# Altium schematic sheet Sheet.SchDoc
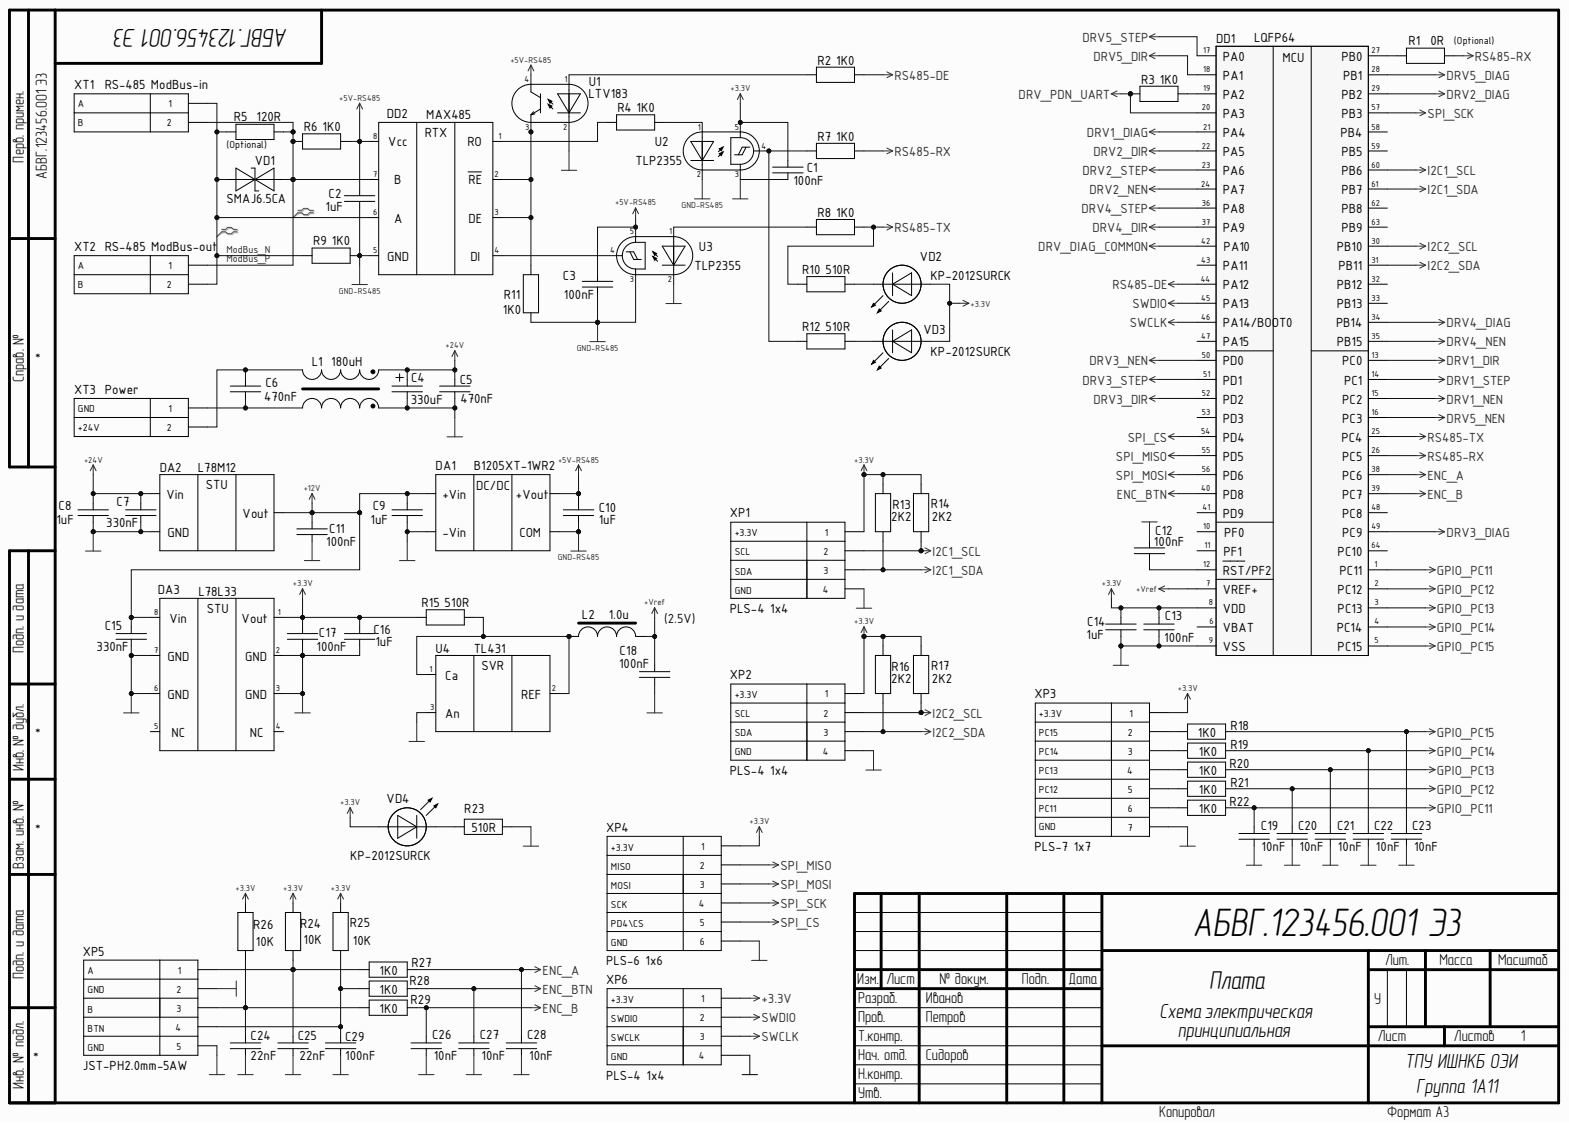
[diagram p0: Sheet.SchDoc]

## Parts
C1  value 100nF  [CAP0805]  (hidden comment: CAP0805 100nF±10%, 50 V, X7R)
C2  value 1uF  [CAP0805]  (hidden comment: CAP0805 1uF±10%, 50 V, X7R)
C3  value 100nF  [CAP0805]  (hidden comment: CAP0805 100nF±10%, 50 V, X7R)
C4  value 330uF  [CapAl10X16X5.0]  (hidden comment: CapAl10X16X5.0mm 330uF, 50 V)
C5  value 470nF  [CAP0805]  (hidden comment: CAP0805 470nF±10%, 50 V, X7R)
C6  value 470nF  [CAP0805]  (hidden comment: CAP0805 470nF±10%, 50 V, X7R)
C7  value 330nF  [CAP0805]  (hidden comment: CAP0805 330nF±10%, 50 V, X7R)
C8  value 1uF  [CAP0805]  (hidden comment: CAP0805 1uF±10%, 50 V, X7R)
C9  value 1uF  [CAP0805]  (hidden comment: CAP0805 1uF±10%, 50 V, X7R)
C10  value 1uF  [CAP0805]  (hidden comment: CAP0805 1uF±10%, 50 V, X7R)
C11  value 100nF  [CAP0805]  (hidden comment: CAP0805 100nF±10%, 50 V, X7R)
C12  value 100nF  [CAP0805]  (hidden comment: CAP0805 100nF±10%, 50 V, X7R)
C13  value 100nF  [CAP0805]  (hidden comment: CAP0805 100nF±10%, 50 V, X7R)
C14  value 1uF  [CAP0805]  (hidden comment: CAP0805 1uF±10%, 50 V, X7R)
C15  value 330nF  [CAP0805]  (hidden comment: CAP0805 330nF±10%, 50 V, X7R)
C16  value 1uF  [CAP0805]  (hidden comment: CAP0805 1uF±10%, 50 V, X7R)
C17  value 100nF  [CAP0805]  (hidden comment: CAP0805 100nF±10%, 50 V, X7R)
C18  value 100nF  [CAP0805]  (hidden comment: CAP0805 100nF±10%, 50 V, X7R)
C19  value 10nF  [CAP0805]  (hidden comment: CAP0805 10nF±5%, 50 V, NP0)
C20  value 10nF  [CAP0805]  (hidden comment: CAP0805 10nF±5%, 50 V, NP0)
C21  value 10nF  [CAP0805]  (hidden comment: CAP0805 10nF±5%, 50 V, NP0)
C22  value 10nF  [CAP0805]  (hidden comment: CAP0805 10nF±5%, 50 V, NP0)
C23  value 10nF  [CAP0805]  (hidden comment: CAP0805 10nF±5%, 50 V, NP0)
C24  value 22nF  [CAP0805]  (hidden comment: CAP0805 22nF±10%, 50 V, X7R)
C25  value 22nF  [CAP0805]  (hidden comment: CAP0805 22nF±10%, 50 V, X7R)
C26  value 10nF  [CAP0805]  (hidden comment: CAP0805 10nF±5%, 50 V, NP0)
C27  value 10nF  [CAP0805]  (hidden comment: CAP0805 10nF±5%, 50 V, NP0)
C28  value 10nF  [CAP0805]  (hidden comment: CAP0805 10nF±5%, 50 V, NP0)
C29  value 100nF  [CAP0805]  (hidden comment: CAP0805 100nF±10%, 50 V, X7R)
DA1  Model: B1205XT-1WR2  [B_XT-1WR2]  (hidden comment: B1205XT-1WR2 (12 V, 5 V))
DA2  Model: L78M12  [D-PAK]  (hidden comment: L78M12 (D-PAK))
DA3  Model: L78L33  [SO-8 Narrow]  (hidden comment: L78L33 (SO-8 Narrow))
DD1  STM32G071RBTx  [LQFP64]  (value not drawn)
DD2  Model: MAX485  [SO-8 Narrow]  (hidden comment: MAX485 (2.5 Mbit, 4.75 V ... 5.25 V ))
L1  value 180uH  [BU10]  (hidden comment: Common Mode Chokes 19*17*22,5mm: 180uH, 1.2A)
L2  value 1.0u  [IND0805]  (hidden comment: IND0805 0805 (2.0*1.25mm) 1.0u)
R1  value 0R  [RES0805]  (hidden comment: RES0805 0R±5%)
R2  value 1K0  [RES0805]  (hidden comment: RES0805 1K0±5%)
R3  value 1K0  [RES0805]  (hidden comment: RES0805 1K0±5%)
R4  value 1K0  [RES0805]  (hidden comment: RES0805 1K0±5%)
R5  value 120R  [RES1210]  (hidden comment: RES1210 120R±5%)
R6  value 1K0  [RES0805]  (hidden comment: RES0805 1K0±5%)
R7  value 1K0  [RES0805]  (hidden comment: RES0805 1K0±5%)
R8  value 1K0  [RES0805]  (hidden comment: RES0805 1K0±5%)
R9  value 1K0  [RES0805]  (hidden comment: RES0805 1K0±5%)
R10  value 510R  [RES0805]  (hidden comment: RES0805 510R±5%)
R11  value 1K0  [RES0805]  (hidden comment: RES0805 1K0±5%)
R12  value 510R  [RES0805]  (hidden comment: RES0805 510R±5%)
R13  value 2K2  [RES0805]  (hidden comment: RES0805 2K2±5%)
R14  value 2K2  [RES0805]  (hidden comment: RES0805 2K2±5%)
R15  value 510R  [RES0805]  (hidden comment: RES0805 510R±5%)
R16  value 2K2  [RES0805]  (hidden comment: RES0805 2K2±5%)
R17  value 2K2  [RES0805]  (hidden comment: RES0805 2K2±5%)
R18  value 1K0  [RES0805]  (hidden comment: RES0805 1K0±5%)
R19  value 1K0  [RES0805]  (hidden comment: RES0805 1K0±5%)
R20  value 1K0  [RES0805]  (hidden comment: RES0805 1K0±5%)
R21  value 1K0  [RES0805]  (hidden comment: RES0805 1K0±5%)
R22  value 1K0  [RES0805]  (hidden comment: RES0805 1K0±5%)
R23  value 510R  [RES0805]  (hidden comment: RES0805 510R±5%)
R24  value 10K  [RES0805]  (hidden comment: RES0805 10K±5%)
R25  value 10K  [RES0805]  (hidden comment: RES0805 10K±5%)
R26  value 10K  [RES0805]  (hidden comment: RES0805 10K±5%)
R27  value 1K0  [RES0805]  (hidden comment: RES0805 1K0±5%)
R28  value 1K0  [RES0805]  (hidden comment: RES0805 1K0±5%)
R29  value 1K0  [RES0805]  (hidden comment: RES0805 1K0±5%)
U1  Model: LTV183  [SOP-4]  (hidden comment: LTV183 (80 V, 50 mA))
U2  Model: TLP2355  [SO-6 5pin]  (hidden comment: TLP2355 (5 Mbit, 2.7 V ... 5.5 V ))
U3  Model: TLP2355  [SO-6 5pin]  (hidden comment: TLP2355 (5 Mbit, 2.7 V ... 5.5 V ))
U4  Model: TL431  [SOT23-3]  (hidden comment: TL431 (SOT23-3))
VD1  Model: SMAJ6.5CA  [SMA Bidirectional]  (hidden comment: SMAJ6.5CA (6.5 V, 400 W, SMA Bidirectional))
VD2  Model: KP-2012SURCK  [LED0805 RED]  (hidden comment: KP-2012SURCK (2.0V, 25mA, LED0805 RED))
VD3  Model: KP-2012SURCK  [LED0805 RED]  (hidden comment: KP-2012SURCK (2.0V, 25mA, LED0805 RED))
VD4  Model: KP-2012SURCK  [LED0805 RED]  (hidden comment: KP-2012SURCK (2.0V, 25mA, LED0805 RED))
XP1  PLS-4 1х4  Pin 1 Name: +3.3V  Pin 2 Name: SCL  Pin 3 Name: SDA  Pin 4 Name: GND  [PLS-1-4]
XP2  PLS-4 1х4  Pin 1 Name: +3.3V  Pin 2 Name: SCL  Pin 3 Name: SDA  Pin 4 Name: GND  [PLS-1-4]
XP3  PLS-7 1х7  Pin 1 Name: +3.3V  Pin 2 Name: PC15  Pin 3 Name: PC14  Pin 4 Name: PC13  Pin 5 Name: PC12  Pin 6 Name: PC11  Pin 7 Name: GND  [PLS-1-7]
XP4  PLS-6 1х6  Pin 1 Name: +3.3V  Pin 2 Name: MISO  Pin 3 Name: MOSI  Pin 4 Name: SCK  Pin 5 Name: PD4\CS  Pin 6 Name: GND  [PLS-1-6]
XP5  JST-PH2.0mm-5AW  Pin 1 Name: A  Pin 2 Name: GND  Pin 3 Name: B  Pin 4 Name: BTN  [JST-PH2.0MM-5AW]
XP6  PLS-4 1х4  Pin 1 Name: +3.3V  Pin 2 Name: SWDIO  Pin 3 Name: SWCLK  Pin 4 Name: GND  [PLS-1-4]
XT1  Name Connector: RS-485 ModBus-in  Pin 1 Name: A  Pin 2 Name: B  [DG306-5.0-2P]  (hidden comment: PCB Screw Terminal Blocks 2-Pin, Pitch 5mm)
XT2  Name Connector: RS-485 ModBus-out  Pin 1 Name: A  Pin 2 Name: B  [DG306-5.0-2P]  (hidden comment: PCB Screw Terminal Blocks 2-Pin, Pitch 5mm)
XT3  Name Connector: Power  Pin 1 Name: GND  Pin 2 Name: +24V  [DG306-5.0-2P]  (hidden comment: PCB Screw Terminal Blocks 2-Pin, Pitch 5mm)

## Nets
+3.3V: C1.2 C13.2 C14.1 C16.1 C17.2 DA3.1(Vout) DD1.8(VDD) R13.2 R14.2 R15.2 R16.2 R17.2 R24.1 R25.1 R26.2 U2.5 VD2.Anode VD3.Anode VD4.Anode XP1.1 XP2.1 XP3.1 XP4.1 XP6.1
+5V-RS485: C2.1 C3.2 C10.2 DA1.+Vout DD2.8(Vcc) R6.2 U1.4 U3.5
+12V: C9.2 C11.2 C15.2 DA1.+Vin DA2.Output DA3.8(Vin)
+24V: C4.1 C5.1 C7.2 C8.2 DA2.Input L1.InA
+Vref: C18.2 DD1.7(VREF+) L2.2
DRV1_DIAG: DD1.21(PA4)
DRV1_DIR: DD1.13(PC0)
DRV1_NEN: DD1.15(PC2)
DRV1_STEP: DD1.14(PC1)
DRV2_DIAG: DD1.29(PB2)
DRV2_DIR: DD1.22(PA5)
DRV2_NEN: DD1.24(PA7)
DRV2_STEP: DD1.23(PA6)
DRV3_DIAG: DD1.49(PC9)
DRV3_DIR: DD1.52(PD2)
DRV3_NEN: DD1.50(PD0)
DRV3_STEP: DD1.51(PD1)
DRV4_DIAG: DD1.34(PB14)
DRV4_DIR: DD1.37(PA9)
DRV4_NEN: DD1.35(PB15)
DRV4_STEP: DD1.36(PA8)
DRV5_DIAG: DD1.28(PB1)
DRV5_DIR: DD1.18(PA1)
DRV5_NEN: DD1.16(PC3)
DRV5_STEP: DD1.17(PA0)
DRV_DIAG_COMMON: DD1.42(PA10)
DRV_PDN_UART: DD1.20(PA3) R3.1
ENC_A: C28.2 DD1.38(PC6) R27.2
ENC_B: C26.2 DD1.39(PC7) R29.2
ENC_BTN: C27.2 DD1.40(PD8) R28.2
GND: C1.1 C4.2 C5.2 C7.1 C8.1 C9.1 C11.1 C12.2 C13.1 C14.2 C15.1 C16.2 C17.1 C18.1 C19.1 C20.1 C21.1 C22.1 C23.1 C24.1 C25.1 C26.1 C27.1 C28.1 C29.1 DA1.-Vin DA2.GND DA3.2(GND) DA3.3(GND) DA3.6(GND) DA3.7(GND) DD1.9(VSS) L1.InB R23.2 U1.2 U2.3 U3.2 U4.3 XP1.4 XP2.4 XP3.7 XP4.6 XP5.2 XP5.5 XP6.4
GND-RS485: C2.2 C3.1 C10.1 DA1.COM DD2.5(GND) R9.2 R11.1 U2.2 U3.3
GPIO_PC11: C19.2 DD1.1(PC11) R22.2
GPIO_PC12: C20.2 DD1.2(PC12) R21.2
GPIO_PC13: C21.2 DD1.3(PC13) R20.2
GPIO_PC14: C22.2 DD1.4(PC14) R19.2
GPIO_PC15: C23.2 DD1.5(PC15) R18.2
I2C1_SCL: DD1.60(PB6) R14.1 XP1.2
I2C1_SDA: DD1.61(PB7) R13.1 XP1.3
I2C2_SCL: DD1.30(PB10) R17.1 XP2.2
I2C2_SDA: DD1.31(PB11) R16.1 XP2.3
RS485-DE: DD1.44(PA12) R2.2
RS485-RX: DD1.26(PC5) R1.2 R7.2
RS485-TX: DD1.25(PC4) R8.2 R10.2
SPI_CS: DD1.54(PD4) XP4.5
SPI_MISO: DD1.55(PD5) XP4.2
SPI_MOSI: DD1.56(PD6) XP4.3
SPI_SCK: DD1.57(PB3) XP4.4
SWCLK: DD1.46(PA14/BOOT0) XP6.3
SWDIO: DD1.45(PA13) XP6.2
ModBus_N: DD2.6(A) R5.1 R9.1 VD1.1 XT1.1 XT2.2
ModBus_P: DD2.7(B) R5.2 R6.1 VD1.2 XT1.2 XT2.1
NetC6_1: C6.1 L1.OutA XT3.2
NetC6_2: C6.2 L1.OutB XT3.1
NetC12_1: C12.1 DD1.12(RST/PF2)
NetC24_2: C24.2 R26.1 R29.1 XP5.3
NetC25_2: C25.2 R24.2 R27.1 XP5.1
NetC29_2: C29.2 R25.2 R28.1 XP5.4
NetDD1_19: DD1.19(PA2) R3.2
NetDD1_27: DD1.27(PB0) R1.1
NetDD2_1: DD2.1(RO) R4.1
NetDD2_2: DD2.2(RE) DD2.3(DE) R11.2 U1.3
NetDD2_4: DD2.4(DI) U3.4
NetL2_1: L2.1 R15.1 U4.1 U4.2
NetR2_1: R2.1 U1.1
NetR4_2: R4.2 U2.1
NetR7_1: R7.1 R12.2 U2.4
NetR8_1: R8.1 U3.1
NetR10_1: R10.1 VD2.Cathode
NetR12_1: R12.1 VD3.Cathode
NetR18_1: R18.1 XP3.2
NetR19_1: R19.1 XP3.3
NetR20_1: R20.1 XP3.4
NetR21_1: R21.1 XP3.5
NetR22_1: R22.1 XP3.6
NetR23_1: R23.1 VD4.Cathode

Not connected: DA3 4(NC) 5(NC); DD1 6(VBAT) 10(PF0) 11(PF1) 32(PB12) 33(PB13) 41(PD9) 43(PA11) 47(PA15) 48(PC8) 53(PD3) 58(PB4) 59(PB5) 62(PB8) 63(PB9) 64(PC10)

## Library data of the parts
C1 C3 C11-C13 C17 C18 C29 = C0066: GENERAL PURPOSE CLASS 2 CERAMIC CAPACITORS CAP0805 100nF±10% 50 V X7R; Manufacture: Yageo; PartNumber: CC0805KRX7R9BB104; Database Table Name: Capacitors SMD$; Tolerance: ±10%; Voltage: 50 V; Case: 0805 (2.0*1.25mm); Component Kind: Standard; ComponentLink1Description: GENERAL PURPOSE CLASS 2 CERAMIC CAPACITORS; Dielectric: X7R; ID: C0066; Library Name: Passive DataBase.DbLib
  C12 C13 C18 C29: ComponentLink1URL: #VALUE!
  C1 C3 C11 C17: ComponentLink1URL: D:\Altium\AD24\Library\Passives Library\DataSheet\General Purpose & High Capacitance CERAMIC CAPACITOR CLASS II (Yageo).pdf
C2 C8-C10 C14 C16 = C0072: GENERAL PURPOSE CLASS 2 CERAMIC CAPACITORS CAP0805 1uF±10% 50 V X7R; Manufacture: Yageo; PartNumber: CC0805KRX7R9BB105; Database Table Name: Capacitors SMD$; Tolerance: ±10%; Voltage: 50 V; Case: 0805 (2.0*1.25mm); Component Kind: Standard; ComponentLink1Description: GENERAL PURPOSE CLASS 2 CERAMIC CAPACITORS; Dielectric: X7R; ID: C0072; Library Name: Passive DataBase.DbLib
  C2 C14 C16: ComponentLink1URL: D:\Altium\AD24\Library\Passives Library\DataSheet\General Purpose & High Capacitance CERAMIC CAPACITOR CLASS II (Yageo).pdf
  C8-C10: ComponentLink1URL: C:\Users\ASGord\OneDrive\Documents\Altium Libraries\Passives Library\DataSheet\General Purpose & High Capacitance CERAMIC CAPACITOR CLASS II (Yageo).pdf
C4 = CAL1102: MINIATURIZED ALUMINUM ELECTROLYTIC CAPACITORS CapAl10X16X5.0 330uF±20% 50 V 105⁰С; Manufacture: Panasonic; PartNumber: EEUHD1H331; Current: 410 mA (100 kHz); Database Table Name: Capacitor Al THD$; Tolerance: ±20%; Voltage: 50 V; Case: 10X16X5.0mm; Component Kind: Standard; ComponentLink1Description: MINIATURIZED ALUMINUM ELECTROLYTIC CAPACITORS; ID: CAL1102; Library Name: Passive DataBase.DbLib; Series: HD; Temperature: 105⁰С; ComponentLink1URL: C:\Users\ASGord\OneDrive\Documents\Altium Libraries\Passives Library\DataSheet\Aluminum Electrolytic Capacitors (Panasonic).pdf
C5 C6 = C0070: GENERAL PURPOSE CLASS 2 CERAMIC CAPACITORS CAP0805 470nF±10% 50 V X7R; Manufacture: Yageo; PartNumber: CC0805KRX7R9BB474; Database Table Name: Capacitors SMD$; Tolerance: ±10%; Voltage: 50 V; Case: 0805 (2.0*1.25mm); Component Kind: Standard; ComponentLink1Description: GENERAL PURPOSE CLASS 2 CERAMIC CAPACITORS; Dielectric: X7R; ID: C0070; Library Name: Passive DataBase.DbLib; ComponentLink1URL: D:\Altium\AD24\Library\Passives Library\DataSheet\General Purpose & High Capacitance CERAMIC CAPACITOR CLASS II (Yageo).pdf
C7 C15 = C0069: GENERAL PURPOSE CLASS 2 CERAMIC CAPACITORS CAP0805 330nF±10% 50 V X7R; Manufacture: Yageo; PartNumber: CC0805KRX7R9BB334; Database Table Name: Capacitors SMD$; Tolerance: ±10%; Voltage: 50 V; Case: 0805 (2.0*1.25mm); Component Kind: Standard; ComponentLink1Description: GENERAL PURPOSE CLASS 2 CERAMIC CAPACITORS; Dielectric: X7R; ID: C0069; Library Name: Passive DataBase.DbLib; ComponentLink1URL: D:\Altium\AD24\Library\Passives Library\DataSheet\General Purpose & High Capacitance CERAMIC CAPACITOR CLASS II (Yageo).pdf
C19-C23 C26-C28 = C0228: GENERAL PURPOSE CLASS 1 CERAMIC CAPACITORS CAP0805 10nF±5% 50 V NP0; Manufacture: Yageo; PartNumber: CC0805JKNPO9BN103; Database Table Name: Capacitors SMD$; Tolerance: ±5%; Voltage: 50 V; Case: 0805 (2.0*1.25mm); Component Kind: Standard; ComponentLink1Description: GENERAL PURPOSE CLASS 1 CERAMIC CAPACITORS; Dielectric: NP0; ID: C0228; Library Name: Passive DataBase.DbLib; ComponentLink1URL: D:\Altium\AD24\Library\Passives Library\DataSheet\General Purpose & High Capacitance CERAMIC CAPACITOR CLASS I (Yageo).pdf
C24 C25 = C0062: GENERAL PURPOSE CLASS 2 CERAMIC CAPACITORS CAP0805 22nF±10% 50 V X7R; Manufacture: Yageo; PartNumber: CC0805KRX7R9BB223; Database Table Name: Capacitors SMD$; Tolerance: ±10%; Voltage: 50 V; Case: 0805 (2.0*1.25mm); Component Kind: Standard; ComponentLink1Description: GENERAL PURPOSE CLASS 2 CERAMIC CAPACITORS; Dielectric: X7R; ID: C0062; Library Name: Passive DataBase.DbLib; ComponentLink1URL: D:\Altium\AD24\Library\Passives Library\DataSheet\General Purpose & High Capacitance CERAMIC CAPACITOR CLASS II (Yageo).pdf
DA1 = DA0069: DC-DC Unregulated Single Output Converter B1205XT-1WR2 (12 V, 5 V, 1W, Mornsun); Database Table Name: DC-DC Converter$; IN Voltage: 12 V; OUT Voltage: 5 V; Component Kind: Standard; ComponentLink1Description: 1W DC-DC Unregulated Single Output Converter; ID: DA0069; Library Name: Power IC Library.DbLib; Manufacture: Mornsun; PartNumber: B1205XT-1WR2; Power: 1W; Series: B_XT-1WR2; ComponentLink1URL: D:\Altium\AD24\Library\myLibrary\Power IC Library\DataSheet\B_XT-1WR2(MORNSUN).pdf
DA2 = DA0014: Positive voltage regulators L78M12 (12 V, 500 mA, D-PAK, STM); PartNumber: L78M12ABDT-TR; Current: 500 mA; Database Table Name: Positive voltage regulators$; Voltage: 12 V; Component Kind: Standard; ComponentLink1Description: Positive voltage regulators L78M00 Series; ID: DA0014; Library Name: Power IC Library.DbLib; Manufacture: STM; Series: L78M05; ComponentLink1URL: D:\Altium\AD24\Library\myLibrary\Power IC Library\DataSheet\L78M00 series (STM).pdf
DA3 = DA0000: Positive voltage regulators L78L33 (3.3 V, 100 mA, SO-8 Narrow, STM); PartNumber: L78L33ABD13TR; Current: 100 mA; Database Table Name: Positive voltage regulators$; Voltage: 3.3 V; Component Kind: Standard; ComponentLink1Description: Positive voltage regulators L78L00 Series; ID: DA0000; Library Name: Power IC Library.DbLib; Manufacture: STM; Series: L78L00; ComponentLink1URL: D:\Altium\AD24\Library\myLibrary\Power IC Library\DataSheet\L78L00 series (STM).pdf
DD1 = STM32G071RBTx: Manufacturer: STMicroelectronics; PartNumber: STM32F303RCT; Flash memory size: 128Kb; RAM memory size: 64Kb; Case: LQFP64; Component Kind: Standard; ComponentLink1Description: STM32G071; Library Name: Microcontrollers Library.IntLib; NetClass: LQFP64; Temperature range: –40 to 85 °C; ComponentLink1URL: C:\Altium Libraries\Microcontrollers Library\DataSheet\STM32F030.pdf
DD2 = U0000: Low-power transceivers for RS-485 and RS-422 communication (2.5Mbit, 4.75-5.25V, SO-8 Narrow); Manufacture: Maxim; PartNumber: MAX485ESA; Database Table Name: Modbus Transceiver$; Qty Channels: 1; Component Kind: Standard; ComponentLink1Description: Low-power transceivers for RS-485 and RS-422 communication; ID: U0000; Library Name: Interface IC DataBase.DbLib; Speed: 2.5Mbit; Supply Voltage: 4.75-5.25V; ComponentLink1URL: D:\Altium\AD24\Library\myLibrary\Interface IC Library\DataSheet\MAX485.pdf
L1 = L0124: Common Mode Chokes Inductor 180uH 1.2A. Case: 19*17*22,5mm; Manufacture: Coilcraft; PartNumber: BU10-1811R2BL; Database Table Name: Inductor THD$; Case: 19*17*22,5mm; Component Kind: Standard; Current: 1.2A; ID: L0124; Library Name: Passive DataBase.DbLib
L2 = L0000: SMALL POWER INDUCTOR 1.0u±20% 3.5A; Manufacture: Samsung; PartNumber: CIGT201208EH1R0MNE; Database Table Name: Inductors SMD$; Tolerance: ±20%; Case: 0805 (2.0*1.25mm); Component Kind: Standard; ComponentLink1Description: SMALL POWER INDUCTOR; ComponentLink1URL: #VALUE!; Current: 3.5A; ID: L0000; Library Name: Passive DataBase.DbLib
R1 = R0356: GENERAL PURPOSE CHIP RESISTORS RES0805 0R±5% 150V 0.125W; Manufacture: Yageo; PartNumber: RC0805JR-070RL; Database Table Name: Resistor SMD 5%$; Tolerance: ±5%; Case: 0805 (2.0*1.25mm); Component Kind: Standard; ComponentLink1Description: GENERAL PURPOSE CHIP RESISTORS; ID: R0356; Library Name: Passive DataBase.DbLib; Power: 0.125W; Voltage: 150V; ComponentLink1URL: D:\Altium\AD24\Library\Passives Library\DataSheet\GENERAL PURPOSE CHIP RESISTORS (Yageo).pdf
R2-R4 R6-R9 R11 R18-R22 R27-R29 = R0429: GENERAL PURPOSE CHIP RESISTORS RES0805 1K0±5% 150V 0.125W; Manufacture: Yageo; PartNumber: RC0805JR-071K0L; Database Table Name: Resistor SMD 5%$; Tolerance: ±5%; Case: 0805 (2.0*1.25mm); Component Kind: Standard; ComponentLink1Description: GENERAL PURPOSE CHIP RESISTORS; ID: R0429; Library Name: Passive DataBase.DbLib; Power: 0.125W; Voltage: 150V; ComponentLink1URL: D:\Altium\AD24\Library\Passives Library\DataSheet\GENERAL PURPOSE CHIP RESISTORS (Yageo).pdf
R5 = R0763: GENERAL PURPOSE CHIP RESISTORS RES1210 120R±5% 200V 0.5W; Manufacture: Yageo; PartNumber: RC1210JR-07120RL; Database Table Name: Resistor SMD 5%$; Tolerance: ±5%; Case: 1210 (3.1*2.6mm); Component Kind: Standard; ComponentLink1Description: GENERAL PURPOSE CHIP RESISTORS; ID: R0763; Library Name: Passive DataBase.DbLib; Power: 0.5W; Voltage: 200V; ComponentLink1URL: D:\Altium\AD24\Library\Passives Library\DataSheet\GENERAL PURPOSE CHIP RESISTORS (Yageo).pdf
R10 R12 R15 R23 = R0422: GENERAL PURPOSE CHIP RESISTORS RES0805 510R±5% 150V 0.125W; Manufacture: Yageo; PartNumber: RC0805JR-07510RL; Database Table Name: Resistor SMD 5%$; Tolerance: ±5%; Case: 0805 (2.0*1.25mm); Component Kind: Standard; ComponentLink1Description: GENERAL PURPOSE CHIP RESISTORS; ID: R0422; Library Name: Passive DataBase.DbLib; Power: 0.125W; Voltage: 150V; ComponentLink1URL: C:\Users\ASGord\OneDrive\Documents\Altium Libraries\Passives Library\DataSheet\GENERAL PURPOSE CHIP RESISTORS (Yageo).pdf
R13 R14 R16 R17 = R0437: GENERAL PURPOSE CHIP RESISTORS RES0805 2K2±5% 150V 0.125W; Manufacture: Yageo; PartNumber: RC0805JR-072K2L; Database Table Name: Resistor SMD 5%$; Tolerance: ±5%; Case: 0805 (2.0*1.25mm); Component Kind: Standard; ComponentLink1Description: GENERAL PURPOSE CHIP RESISTORS; ID: R0437; Library Name: Passive DataBase.DbLib; Power: 0.125W; Voltage: 150V; ComponentLink1URL: D:\Altium\AD24\Library\Passives Library\DataSheet\GENERAL PURPOSE CHIP RESISTORS (Yageo).pdf
R24-R26 = R0453: GENERAL PURPOSE CHIP RESISTORS RES0805 10K±5% 150V 0.125W; Manufacture: Yageo; PartNumber: RC0805JR-0710KL; Database Table Name: Resistor SMD 5%$; Tolerance: ±5%; Case: 0805 (2.0*1.25mm); Component Kind: Standard; ComponentLink1Description: GENERAL PURPOSE CHIP RESISTORS; ID: R0453; Library Name: Passive DataBase.DbLib; Power: 0.125W; Voltage: 150V; ComponentLink1URL: D:\Altium\AD24\Library\Passives Library\DataSheet\GENERAL PURPOSE CHIP RESISTORS (Yageo).pdf
U1 = U0002: TLP183 Phototransistor Optocoupler (80 V, 50 mA, SOP-4); Manufacture: Toshiba; PartNumber: TLP183(BL-TPL,E; Current: 50 mA; Database Table Name: Optocoupler Transistor$; Qty Channels: 1; Voltage: 80 V; Component Kind: Standard; ComponentLink1Description: TLP183 Phototransistor Optocoupler; ID: U0002; Library Name: Interface IC DataBase.DbLib; ComponentLink1URL: D:\Altium\AD24\Library\myLibrary\Interface IC Library\DataSheet\TLP183(Toshiba).pdf
U2 U3 = U0001: TLP2355 Buffer logic type, 5 MBd Digital Optocoupler (5 Mbit, 2.7 V ... 5.5 V, SO-6 5pin); Manufacture: Toshiba; Database Table Name: Optocoupler Digital$; Qty Channels: 1; Speed: 5 Mbit; Component Kind: Standard; ComponentLink1Description: TLP2355 Buffer logic type, 5 MBd Digital Optocoupler; ID: U0001; Library Name: Interface IC DataBase.DbLib; PartNumber: TLP2355; Supply Voltage: 2.7 V ... 5.5 V; ComponentLink1URL: D:\Altium\AD24\Library\myLibrary\Interface IC Library\DataSheet\TLP2355.pdf
U4 = DA0000: Positive voltage regulators TL431 (2.495…36 V, 100 mA, SOT23-3, TI); PartNumber: TL431ACDBZR; Current: 100 mA; Database Table Name: Voltage Reference$; Component Kind: Standard; ComponentLink1Description: TL43xx Precision Programmable Reference; ID: DA0000; Library Name: Power IC Library.DbLib; Manufacture: TI; Series: TL43xx; Voltage: 2.495…36 V; ComponentLink1URL: D:\Altium\AD24\Library\myLibrary\Power IC Library\DataSheet\TL43xx series (TI).pdf
VD1 = VD0195: TVS DIODE SMAJ6.5CA (6.5 V, 400 W, SMA Bidirectional, STM); PartNumber: SMAJ6.5CA-TR; Database Table Name: DIODES TVS$; Power: 400 W; Voltage: 6.5 V; Case: SMA; Component Kind: Standard; ComponentLink1Description: SMAJ6.5CA Transient Voltage Suppressors; ID: VD0195; Library Name: Discrete Semiconductors DataBase.DbLib; Manufacture: STM; ComponentLink1URL: D:\Altium\AD24\Library\myLibrary\Discrete Semiconductors Library\DataSheet\SMAJ Series (STM).pdf
VD2-VD4 = VD005: LED SMD KP-2012SURCK (2.0V, 25mA, LED0805 RED); Color: SUPER BRIGHT RED; Database Table Name: LED SMD$; Luminous Intensity: 80mcd; Case: 0805; Component Kind: Standard; ComponentLink1Description: SMD Red LED 0805; Current: 25mA; ID: VD005; Library Name: Discrete Semiconductors DataBase.DbLib; PartNumber: KP-2012SURCK; Voltage: 2.0V; ComponentLink1URL: D:\Altium\AD24\Library\myLibrary\Discrete Semiconductors Library\DataSheet\KP-2012SURCK(KGB).pdf
XP1 XP2 XP6 = PLS-4 1х4: PLS-4, Вилка штыревая 2.54мм 1х4pin прямая (тип D1); Component Kind: Standard; Library Name: Connectors Library.IntLib
XP3 = PLS-7 1х7: PLS-7, Вилка штыревая 2.54мм 1х7pin прямая (тип D1); Component Kind: Standard; Library Name: Connectors Library.IntLib
XP4 = PLS-6 1х6: PLS-6, Вилка штыревая 2.54мм 1х6pin прямая (тип D1); Component Kind: Standard; Library Name: Connectors Library.IntLib
XP5 = JST-PH2.0mm-5AW: JST-PH2.0mm-5AW Male Curved Needle Plug; System: Connector; Component Kind: Standard; Library Name: Connectors Library.IntLib; Pin 5 Name: GND
XT1-XT3 = DG306-5.0-2P-12: PCB Screw Terminal Blocks 2-Pole, Pitch 5mm, 250V, 15A per Pin; Manufacture: Degson; Component Kind: Standard; ComponentLink1Description: DG306-5.0 Series Connector (Degson); ComponentLink1URL: http://www.degson.com/en/downpdf/id/948.html; Library Name: Connectors Library.IntLib; PartNumber: DG306-5.0-2P-12
